# Altium schematic sheet Power_MCU.SchDoc(Power_MCU)
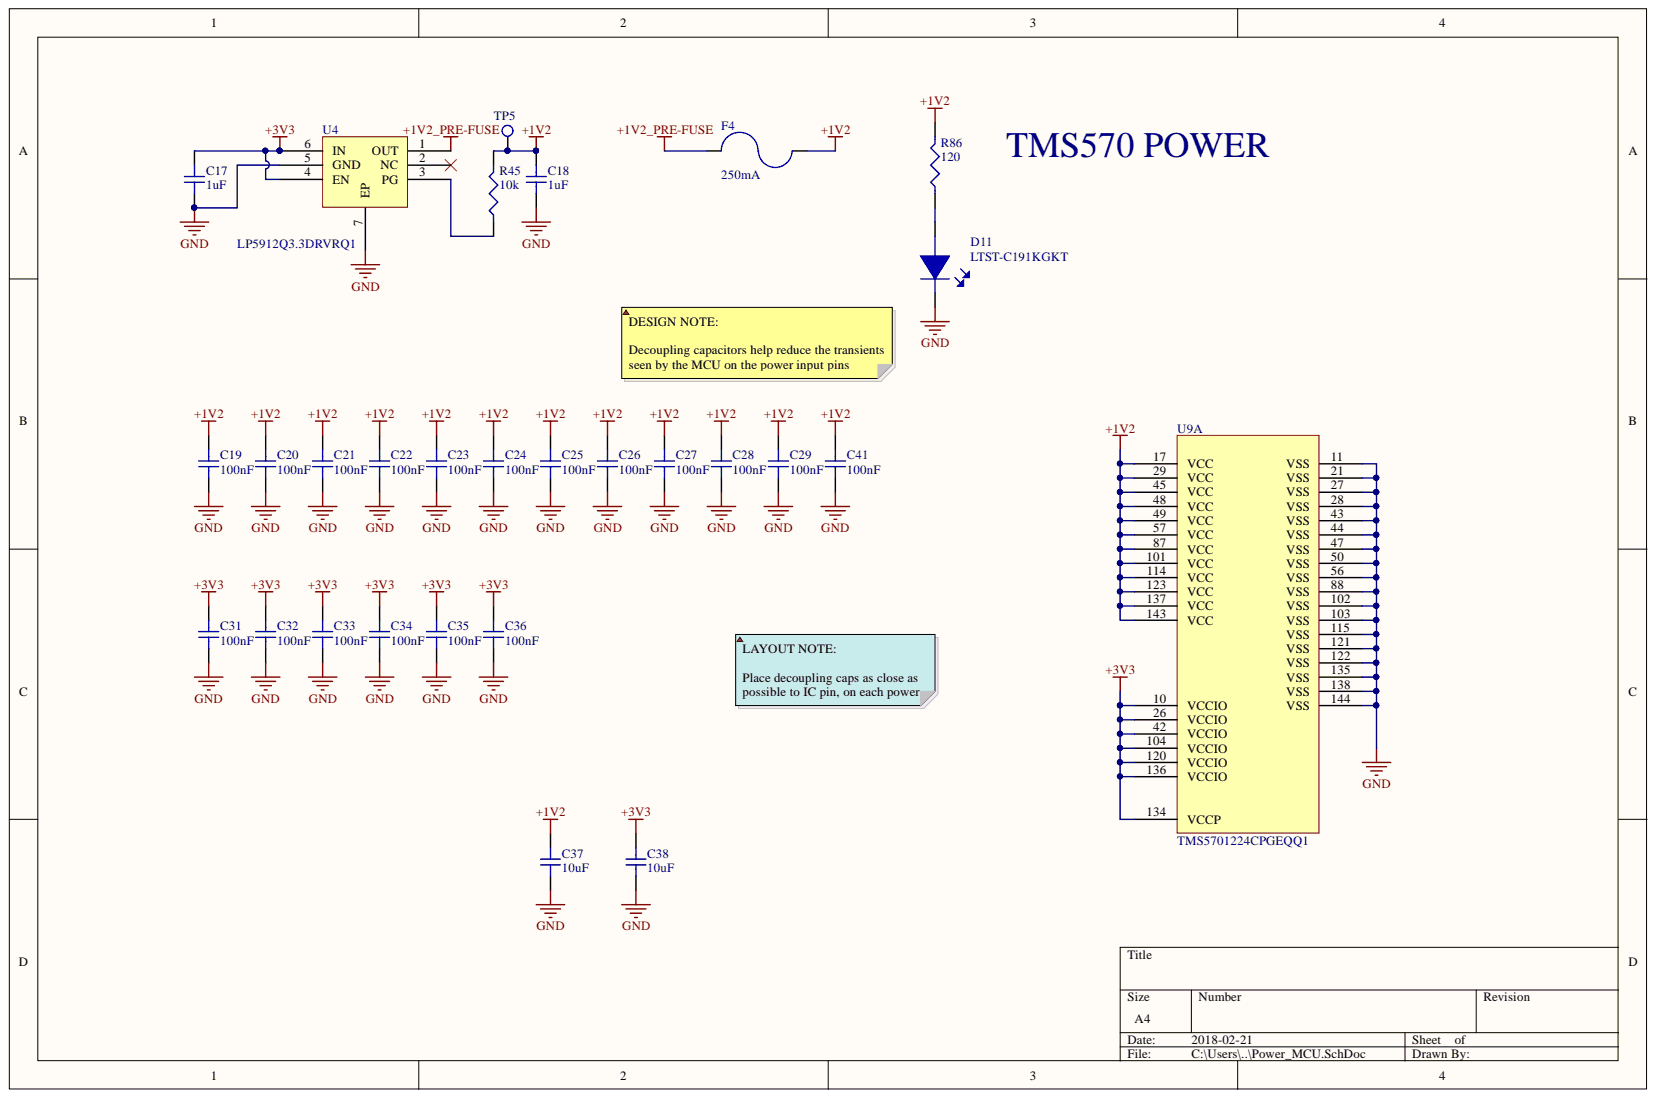
[diagram p0: Power_MCU.SchDoc]

## Parts
C17  1uF  [C0805]
C18  1uF  [C0805]
C19  100nF  [C0805]
C20  100nF  [C0805]
C21  100nF  [C0805]
C22  100nF  [C0805]
C23  100nF  [C0805]
C24  100nF  [C0805]
C25  100nF  [C0805]
C26  100nF  [C0805]
C27  100nF  [C0805]
C28  100nF  [C0805]
C29  100nF  [C0805]
C31  100nF  [C0805]
C32  100nF  [C0805]
C33  100nF  [C0805]
C34  100nF  [C0805]
C35  100nF  [C0805]
C36  100nF  [C0805]
C37  10uF  [TANT_10u]
C38  10uF  [TANT_10u]
C41  100nF  [C0805]
D11  LTST-C191KGKT  [LED_0603]
F4  250mA  [FUSE_0603]
R45  10k  [R0805]
R86  120  [R0805]
TP5  5015  [TP_HOOK]  (value not drawn)
U4  LP5912Q3.3DRVRQ1  [WSON]
U9  TMS5701224CPGEQQ1  [LQFP-144]  (part A)

## Nets
+1V2: C18.2 C19.2 C20.2 C21.2 C22.2 C23.2 C24.2 C25.2 C26.2 C27.2 C28.2 C29.2 C37.1 C41.2 F4.2 R45.2 R86.2 TP5.1 U9.17(VCC) U9.29(VCC) U9.45(VCC) U9.48(VCC) U9.49(VCC) U9.57(VCC) U9.87(VCC) U9.101(VCC) U9.114(VCC) U9.123(VCC) U9.137(VCC) U9.143(VCC)
+1V2_PRE-FUSE: F4.1 U4.1(OUT)
+3V3: C17.2 C31.2 C32.2 C33.2 C34.2 C35.2 C36.2 C38.1 U4.4(EN) U4.6(IN) U9.10(VCCIO) U9.26(VCCIO) U9.42(VCCIO) U9.104(VCCIO) U9.120(VCCIO) U9.134(VCCP) U9.136(VCCIO)
GND: C17.1 C18.1 C19.1 C20.1 C21.1 C22.1 C23.1 C24.1 C25.1 C26.1 C27.1 C28.1 C29.1 C31.1 C32.1 C33.1 C34.1 C35.1 C36.1 C37.2 C38.2 C41.1 D11.2 U4.5(GND) U4.7(EP) U9.11(VSS) U9.21(VSS) U9.27(VSS) U9.28(VSS) U9.43(VSS) U9.44(VSS) U9.47(VSS) U9.50(VSS) U9.56(VSS) U9.88(VSS) U9.102(VSS) U9.103(VSS) U9.115(VSS) U9.121(VSS) U9.122(VSS) U9.135(VSS) U9.138(VSS) U9.144(VSS)
NetD11_1: D11.1 R86.1
NetR45_1: R45.1 U4.3(PG)

Not connected: U4 2(NC)

## Library data of the parts
C17 C18 = 0805ZC105KAT2A: CAP CER 1UF 10V X7R 0805; Manufacturer: Kyocera AVX; Tolerance: 10%; Insulation Resistance: 100GR; Dissipation Factor: 5%; Width: 1.25mm; Voltage Rating (DC): 10V; Voltage Rating: 10V; Voltage: 10V; Thickness: 0.055inch; Termination: SMD/SMT; Supplier Part Number 1: 478-1405-1-ND; Supplier 1: Digi-Key; Stock 1: 385183; REACH SVHC: No SVHC; Radiation Hardening: No; Pricing 1: 1=0.21, 10=0.147, 100=0.069, 500=0.0484, 1000=0.0401 (USD); Packaging: Tape and Reel; Package Quantity: 1; Number of Pins: 2; Mount: Surface Mount,PCB; Min Operating Temperature: -55°C; Max Operating Temperature: 125°C; Manufacturer Part Number: 0805ZC105KAT2A; Length: 2.01mm; Lead Free: Lead Free; Height: 1.4mm; Dielectric: X7R; Depth: 1.25mm; Contact Plating: Tin,Matte; ComponentLink12URL: https://datasheet.ciiva.com/19475/2011248-19475855.pdf; ComponentLink12Description: https://datasheet.ciiva.com/19475/2011248-19475855.pdf; ComponentLink11URL: https://datasheet.ciiva.com/7812/84526-7812223.pdf; ComponentLink11Description: https://datasheet.ciiva.com/7812/84526-7812223.pdf; ComponentLink10URL: https://datasheet.ciiva.com/11787/0900766b80ed4be2-11787102.pdf; ComponentLink10Description: https://datasheet.ciiva.com/11787/0900766b80ed4be2-11787102.pdf; ComponentLink9URL: https://datasheet.ciiva.com/151/cx7r-151918.pdf; ComponentLink9Description: https://datasheet.ciiva.com/151/cx7r-151918.pdf; ComponentLink8URL: https://datasheet.ciiva.com/151/cx7r-151917.pdf; ComponentLink8Description: https://datasheet.ciiva.com/151/cx7r-151917.pdf; ComponentLink7URL: https://datasheet.ciiva.com/151/cx7r-151916.pdf; ComponentLink7Description: https://datasheet.ciiva.com/151/cx7r-151916.pdf; ComponentLink6URL: https://datasheet.ciiva.com/15165/getdatasheetpartid-71567-15165451.pdf; ComponentLink6Description: https://datasheet.ciiva.com/15165/getdatasheetpartid-71567-15165451.pdf; ComponentLink5URL: https://datasheet.ciiva.com/25901/cx7r-25901193.pdf; ComponentLink5Description: https://datasheet.ciiva.com/25901/cx7r-25901193.pdf; ComponentLink4URL: https://datasheet.ciiva.com/14594/70001075-14594329.pdf; ComponentLink4Description: https://datasheet.ciiva.com/14594/70001075-14594329.pdf; ComponentLink3URL: https://datasheet.ciiva.com/14601/70001075-14601048.pdf; ComponentLink3Description: https://datasheet.ciiva.com/14601/70001075-14601048.pdf; ComponentLink2URL: https://datasheet.ciiva.com/2988/cx7r-2988072.pdf; ComponentLink2Description: https://datasheet.ciiva.com/2988/cx7r-2988072.pdf; ComponentLink1URL: https://datasheet.ciiva.com/2988/cx7r-2988071.pdf; ComponentLink1Description: https://datasheet.ciiva.com/2988/cx7r-2988071.pdf; Component Kind: Standard; Case Code (Metric): 2012; Case Code (Imperial): 0805; Case/Package: 0805; Capacitance: 1uF; Library Name: PHANTOM_LIBRARY.SchLib
C19-C29 C31-C36 C41 = GRM21B7U1A104JA01L: CAP MLCC 100nF 10Volts U2J 5%; Manufacturer: Murata; Voltage Rating (DC): 10V; Width: 0.049inch; Voltage Rating: 10V; Tolerance: 5%; Thickness: 0.053inch; Termination: SMD/SMT; Supplier Part Number 1: 490-11592-6-ND; Supplier 1: Digi-Key; Stock 1: 6000; Radiation Hardening: No; Pricing 1: 1=0.46, 10=0.32, 100=0.192, 500=0.144, 1000=0.1216 (USD); Packaging: Tape and Reel; Package Quantity: 3000; Mount: Surface Mount; Min Operating Temperature: -55°C; Max Operating Temperature: 125°C; Manufacturer Part Number: GRM21B7U1A104JA01L; Length: 2mm; Height: 1.25mm; Dielectric: U2J; Depth: 1.25mm; ComponentLink3URL: https://datasheet.ciiva.com/11618/product-11618924.pdf; ComponentLink3Description: https://datasheet.ciiva.com/11618/product-11618924.pdf; ComponentLink2URL: https://datasheet.ciiva.com/17451/product-17451845.pdf; ComponentLink2Description: https://datasheet.ciiva.com/17451/product-17451845.pdf; ComponentLink1URL: https://datasheet.ciiva.com/5424/product-5424660.pdf; ComponentLink1Description: https://datasheet.ciiva.com/5424/product-5424660.pdf; Component Kind: Standard; Case Code (Metric): 2012; Case Code (Imperial): 0805; Case/Package: 0805; Capacitance: 100nF; Library Name: PHANTOM_LIBRARY.SchLib
C37 C38 = F381A106MMA: CAP TANTALUM 10uF, 0603 [1608 Metric], 0.5 ohm, 10 V AVX  Surface Mount Tantalum Capacitor, F38 Series, 10uF, 0603 [1608 Metric], 0.5 ohm, 10 V; Manufacturer: Kyocera AVX; Voltage Rating (DC): 10V; Tolerance: 20%; Life (Hours): 1000Hour; Leakage Current: 10uA; ESR (Equivalent Series Resistance): 500mR; Dissipation Factor: 15%; Width: 0.033inch; Weight: 7.1E-05oz; Voltage Rating: 10V; Termination: SMD/SMT; Supplier Part Number 1: 478-9527-1-ND; Supplier 1: Digi-Key; Stock 1: 7989; REACH SVHC: No SVHC; Radiation Hardening: No; Pricing 1: 1=0.75, 10=0.551, 100=0.3779, 500=0.2992, 1000=0.2519 (USD); Packaging: Tape and Reel; Package Quantity: 4000; Min Operating Temperature: -55°C; Max Operating Temperature: 105°C; Manufacturer Part Number: F381A106MMA; Length: 1.6mm; Height - Seated (Max): 0.035inch; Height: 0.8mm; Depth: 0.85mm; ComponentLink3URL: https://datasheet.ciiva.com/46417/1805432-46417518.pdf; ComponentLink3Description: https://datasheet.ciiva.com/46417/1805432-46417518.pdf; ComponentLink2URL: https://datasheet.ciiva.com/46417/1805432-46417517.pdf; ComponentLink2Description: https://datasheet.ciiva.com/46417/1805432-46417517.pdf; ComponentLink1URL: https://datasheet.ciiva.com/48510/f38-48510901.pdf; ComponentLink1Description: https://datasheet.ciiva.com/48510/f38-48510901.pdf; Component Kind: Standard; Case Code (Metric): 1608; Case Code (Imperial): 0603; Case/Package: 0603; Capacitance: 10uF; Library Name: PHANTOM_LIBRARY.SchLib
D11 = LTST-C191KGKT: LED GREEN CLEAR 0603 SMD; Manufacturer: Vishay Lite-On; Wavelength: 574nm; Viewing Angle: 130°; Test Current: 20mA; Power Rating: 75mW; Number of LEDs: 1; Luminous Intensity: 35mcd; Lens Style: Clear,Diffused,Colorless,Rectangular; Lens Colour: Clear,Colorless; Forward Voltage: 2V; Forward Current: 30mA; Dominant Wavelength: 571nm; Width: 0.8mm; Supplier Part Number 1: 160-1446-6-ND; Supplier 1: Digi-Key; Stock 1: 1301664; Radiation Hardening: No; Pricing 1: 1=0.29, 10=0.211, 25=0.1556, 100=0.1111, 250=0.0756, 500=0.0667, 1000=0.0522, 2500=0.0511 (USD); Power Dissipation: 75mW; Packaging: Tape and Reel; Package Quantity: 1; Number of Pins: 2; Number of Elements: 1; Mount: Surface Mount; Min Operating Temperature: -55°C; Max Operating Temperature: 85°C; Manufacturer Part Number: LTST-C191KGKT; Lens Transparency: Clear; Length: 1.6mm; Lead Free: Lead Free; Illumination Colour: Green; Height: 0.55mm; Depth: 0.8mm; ComponentLink7URL: https://datasheet.ciiva.com/16215/ltst-c191kgkt-16215151.pdf; ComponentLink7Description: https://datasheet.ciiva.com/16215/ltst-c191kgkt-16215151.pdf; ComponentLink6URL: https://datasheet.ciiva.com/11773/0900766b80dc02b1-11773629.pdf; ComponentLink6Description: https://datasheet.ciiva.com/11773/0900766b80dc02b1-11773629.pdf; ComponentLink5URL: https://datasheet.ciiva.com/15223/getdatasheetpartid-103913-15223276.pdf; ComponentLink5Description: https://datasheet.ciiva.com/15223/getdatasheetpartid-103913-15223276.pdf; ComponentLink4URL: https://datasheet.ciiva.com/2109/s-110-ltst-c191kgkt-2109975.pdf; ComponentLink4Description: https://datasheet.ciiva.com/2109/s-110-ltst-c191kgkt-2109975.pdf; ComponentLink3URL: https://datasheet.ciiva.com/2109/s-110-ltst-c191kgkt-2109974.pdf; ComponentLink3Description: https://datasheet.ciiva.com/2109/s-110-ltst-c191kgkt-2109974.pdf; ComponentLink2URL: https://datasheet.ciiva.com/2109/s-110-ltst-c191kgkt-2109973.pdf; ComponentLink2Description: https://datasheet.ciiva.com/2109/s-110-ltst-c191kgkt-2109973.pdf; ComponentLink1URL: https://datasheet.ciiva.com/12400/100-ltst-c191kgkt-53586-12400818.pdf; ComponentLink1Description: https://datasheet.ciiva.com/12400/100-ltst-c191kgkt-53586-12400818.pdf; Component Kind: Standard; Colour: Green; Case Code (Metric): 1608; Case Code (Imperial): 0603; Case/Package: 0603; Library Name: PHANTOM_LIBRARY.SchLib
F4 = C2Q250: FUSE_0.25A SMD 0603 BOARD MNT 250MA 32VAC 63VDC; Manufacturer: Bel; Voltage Rating (DC): 63V; Voltage Rating (AC): 32V; Power Dissipation: 80mW; Fuse Type: Board Mount (Cartridge Style Excluded); DC Cold Resistance: 700mR; Approvals: CE,cULus,cURus; Width: 0.032inch; Voltage Rating: 32V; Supplier Part Number 1: 507-1086-1-ND; Supplier 1: Digi-Key; Stock 1: 88874; Pricing 1: 1=0.33, 5=0.322, 10=0.308, 50=0.2746, 100=0.2557, 250=0.2273, 500=0.1894, 1000=0.18 (USD); Packaging: Tape and Reel; Number of Pins: 2; Mount: Surface Mount; Min Operating Temperature: -55°C; Max Voltage Rating (DC): 63V; Max Operating Temperature: 125°C; Manufacturer Part Number: C2Q250; Length: 0.063inch; Lead Free: Lead Free; Housing Material: Ceramic; Height: 0.019inch; Depth: 0.82mm; Current Rating: 250mA; Contact Plating: Tin; ComponentLink4URL: https://datasheet.ciiva.com/8264/c2q-8264041.pdf; ComponentLink4Description: https://datasheet.ciiva.com/8264/c2q-8264041.pdf; ComponentLink3URL: https://datasheet.ciiva.com/300/c2q-300601.pdf; ComponentLink3Description: https://datasheet.ciiva.com/300/c2q-300601.pdf; ComponentLink2URL: https://datasheet.ciiva.com/300/c2q-300600.pdf; ComponentLink2Description: https://datasheet.ciiva.com/300/c2q-300600.pdf; ComponentLink1URL: https://datasheet.ciiva.com/300/c2q-300599.pdf; ComponentLink1Description: https://datasheet.ciiva.com/300/c2q-300599.pdf; Component Kind: Standard; Case Code (Metric): 1608; Case Code (Imperial): 0603; Case/Package: 0603; Library Name: PHANTOM_LIBRARY.SchLib
R45 = RC0805FR-071KL: RES SMD 10K OHM 1% 1/8W 0805; Manufacturer: Yageo Phycomp; Voltage Rating (DC): 150V; Temperature Coefficient: 100ppm/°C; Resistance: 1KR; Power Rating: 125mW; Width: 1.25mm; Tolerance: 1%; Supplier 1: Digi-Key; Stock 1: 5527973; REACH SVHC: No SVHC; Pricing 1: 1=0.1, 10=0.022, 100=0.0088, 1000=0.004, 2500=0.0034 (USD); Packaging: Cut Tape; Number of Pins: 2; Min Operating Temperature: -55°C; Max Operating Temperature: 155°C; Manufacturer Part Number: RC0805FR-071KL; Length: 2mm; Lead Free: Lead Free; Height: 0.5mm; Depth: 1.25mm; Component Kind: Standard; Case/Package: 0805; Library Name: PHANTOM_LIBRARY.SchLib
R86 = RC0805FR-07120RL: RES SMD 120 OHM 1% 1/8W 0805; Manufacturer: Yageo; Voltage Rating: 150V; Temperature Coefficient: 100ppm/°C; Resistance: 120R; Power Rating: 125mW; Width: 0.049inch; Tolerance: 1%; Termination: SMD/SMT; Supplier Part Number 1: 311-120CRCT-ND; Supplier 1: Digi-Key; Stock 1: 632664; REACH SVHC: Unknown; Pricing 1: 1=0.1, 10=0.022, 100=0.0088, 1000=0.004, 2500=0.0034 (USD); Packaging: Tape and Reel; Package Quantity: 1; Number of Terminations: 2; Min Operating Temperature: -55°C; Military Standard: Not; Max Power Dissipation: 125mW; Max Operating Temperature: 155°C; Manufacturer Part Number: RC0805FR-07120RL; Length: 2mm; Lead Free: Lead Free; Height: 0.5mm; Depth: 1.25mm; Composition: Thick Film; ComponentLink8URL: https://datasheet.ciiva.com/16964/rc0805-16964606.pdf; ComponentLink8Description: https://datasheet.ciiva.com/16964/rc0805-16964606.pdf; ComponentLink7URL: https://datasheet.ciiva.com/7941/444164-7941692.pdf; ComponentLink7Description: https://datasheet.ciiva.com/7941/444164-7941692.pdf; ComponentLink6URL: https://datasheet.ciiva.com/7941/444164-7941691.pdf; ComponentLink6Description: https://datasheet.ciiva.com/7941/444164-7941691.pdf; ComponentLink5URL: https://datasheet.ciiva.com/11819/0900766b8106beab-11819786.pdf; ComponentLink5Description: https://datasheet.ciiva.com/11819/0900766b8106beab-11819786.pdf; ComponentLink4URL: https://datasheet.ciiva.com/12558/rc0805-257173-12558661.pdf; ComponentLink4Description: https://datasheet.ciiva.com/12558/rc0805-257173-12558661.pdf; ComponentLink3URL: https://datasheet.ciiva.com/2491/pyu-r-int-thick-7-2491587.pdf; ComponentLink3Description: https://datasheet.ciiva.com/2491/pyu-r-int-thick-7-2491587.pdf; ComponentLink2URL: https://datasheet.ciiva.com/2491/pyu-r-int-thick-7-2491586.pdf; ComponentLink2Description: https://datasheet.ciiva.com/2491/pyu-r-int-thick-7-2491586.pdf; ComponentLink1URL: https://datasheet.ciiva.com/2491/pyu-r-int-thick-7-2491585.pdf; ComponentLink1Description: https://datasheet.ciiva.com/2491/pyu-r-int-thick-7-2491585.pdf; Component Kind: Standard; Case Code (Metric): 2012; Case Code (Imperial): 0805; Case/Package: 0805; Library Name: PHANTOM_LIBRARY.SchLib
TP5 = 5015: TEST POINT, PCB, SMT; Manufacturer: Keystone Electronics; Number of Ports: 1; Thickness: 0.01inch; Termination: Solder; Supplier Part Number 1: 36-5015CT-ND; Supplier 1: Digi-Key; Stock 1: 1937775; REACH SVHC: No SVHC; Pricing 1: 1=0.44, 10=0.421, 25=0.3604, 50=0.3064, 100=0.2944, 250=0.2644, 500=0.2524 (USD); Packaging: Tape and Reel; Package Quantity: 1; Orientation: Straight; Mount: Surface Mount; Material: Bronze,Silver; Manufacturer Part Number: 5015; Length: 2.66mm; Lead Free: Lead Free; Height: 0.06inch; Depth: 1.01mm; Contact Plating: Tin,Silver,Lead; Contact Material: Bronze; ComponentLink4URL: https://datasheet.ciiva.com/16883/70182998-16883734.pdf; ComponentLink4Description: https://datasheet.ciiva.com/16883/70182998-16883734.pdf; ComponentLink3URL: https://datasheet.ciiva.com/1123/5015-1123862.pdf; ComponentLink3Description: https://datasheet.ciiva.com/1123/5015-1123862.pdf; ComponentLink2URL: https://datasheet.ciiva.com/2917/5015-2917617.pdf; ComponentLink2Description: https://datasheet.ciiva.com/2917/5015-2917617.pdf; ComponentLink1URL: https://datasheet.ciiva.com/2917/5015-2917616.pdf; ComponentLink1Description: https://datasheet.ciiva.com/2917/5015-2917616.pdf; Component Kind: Standard; Colour: White; Library Name: PHANTOM_LIBRARY.SchLib
U4 = LP5912Q3.3DRVRQ1: LDO_1V2 REG 3.3V INPUT 1V2 OUTPUT 0.5A; Manufacturer: Texas Instruments; Quiescent Current: 30uA; Dropout Voltage: 150mV; Supplier Part Number 1: 296-44294-1-ND; Supplier 1: Digi-Key; Stock 1: 10415; Pricing 1: 1=1.41, 10=1.261, 100=0.9831, 500=0.8122, 1000=0.6412 (USD); Min Operating Temperature: -40°C; Max Operating Temperature: 125°C; Manufacturer Part Number: LP5912Q3.3DRVRQ1; Lead Free: Lead Free; ComponentLink1URL: http://www.ti.com/lit/ds/symlink/lp5912-q1.pdf; ComponentLink1Description: http://www.ti.com/lit/ds/symlink/lp5912-q1.pdf; Component Kind: Standard; Library Name: PHANTOM_LIBRARY.SchLib
U9 = TMS5701224CPGEQQ1: ARM CORTEX R4 LOCK STEP HERCULES MCU; Manufacturer: Texas Instruments; Watchdog Timer: Yes; RAM Size: 192KB; Peripherals: POR,PWM,WDT,DMA; Oscillator Type: External; Number of Timers/Counters: 2; Number of Programmable I/O: 64; Memory Type: FLASH; Memory Size: 1.25MB; Max Frequency: 160MHz; Interface: I2C,SPI,CAN,UART,USART,LIN,SCI,EBI/EMI; Density: 1310720B; Supplier Part Number 1: 296-47200-ND; Supplier 1: Digi-Key; Stock 1: 113; Pricing 1: 1=22.12, 10=20.402, 100=17.4222, 500=15.8176 (USD); Packaging: Tray; Number of Pins: 144; Number of I/Os: 64; Number of GPIO: 64; Min Operating Temperature: -40°C; Max Operating Temperature: 125°C; Manufacturer Part Number: TMS5701224CPGEQQ1; Lead Free: Lead Free; Frequency: 160MHz; Core Architecture: ARM; ComponentLink3URL: http://www.ti.com/general/docs/lit/getliterature.tsp?genericPartNumber=TMS570LS1224&&fileType=pdf; ComponentLink3Description: http://www.ti.com/general/docs/lit/getliterature.tsp?genericPartNumber=TMS570LS1224&&fileType=pdf; ComponentLink2URL: http://www.ti.com/lit/gpn/tms570ls1224; ComponentLink2Description: http://www.ti.com/lit/gpn/tms570ls1224; ComponentLink1URL: http://www.ti.com/lit/pdf/spnu515; ComponentLink1Description: http://www.ti.com/lit/pdf/spnu515; Component Kind: Standard; Case/Package: LQFP; Library Name: PHANTOM_LIBRARY.SchLib
